# Altium schematic sheet Power.SchDoc(Power)
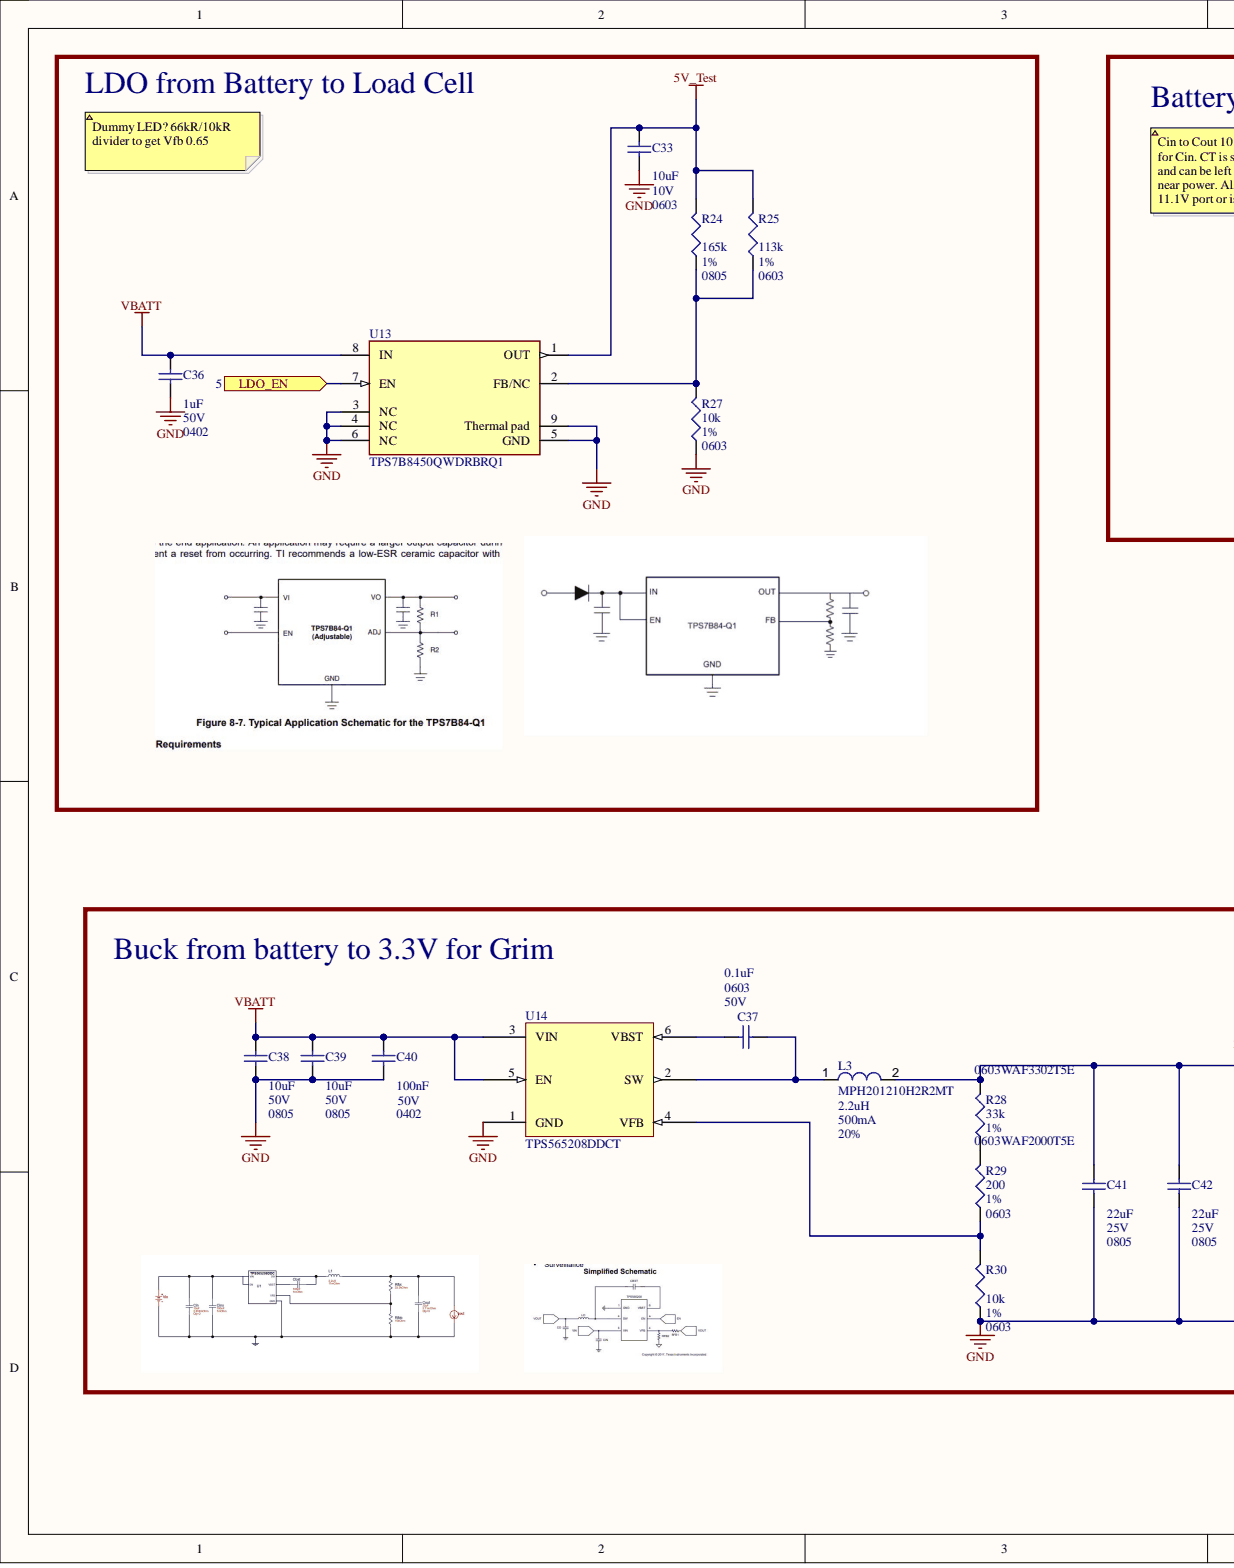
[diagram p0: Power.SchDoc, left]
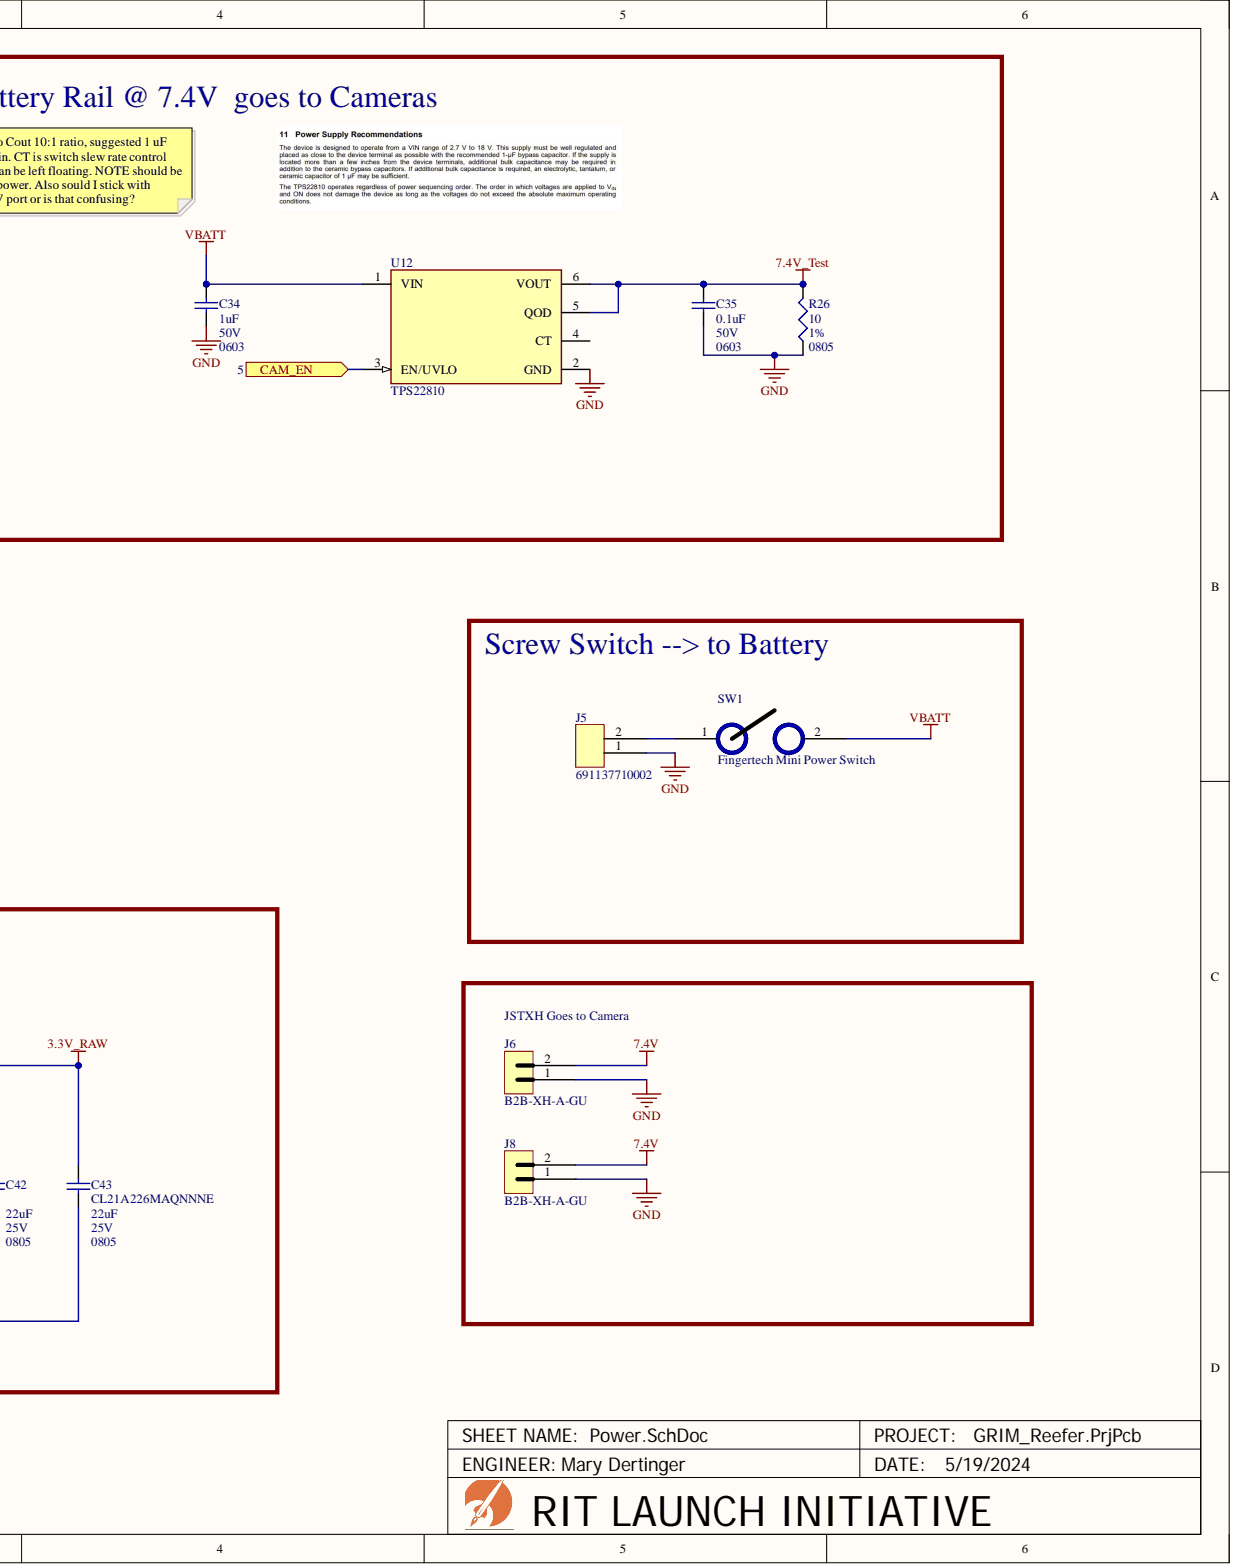
[diagram p1: Power.SchDoc, right]

## Parts
C33  Capacitance: 10uF  Voltage: 10V  (hidden comment: CL10A106KP8NNNC)
C34  Capacitance: 1uF  Case Code (Imperial): 0603  Voltage: 50V  (hidden comment: CL10A105KB8NNNC)
C35  Capacitance: 0.1uF  Case Code (Imperial): 0603  Voltage: 50V  (hidden comment: CC0603KRX7R9BB104)
C36  Capacitance: 1uF  Case/Package: 0402  Voltage: 50V  (hidden comment: CL05A105KA5NQNC)
C37  Case Code (Imperial): 0603  Voltage: 50V  (hidden comment: CC0603KRX7R9BB104)
C38  Capacitance: 10uF  Case/Package: 0805  Voltage: 50V  (hidden comment: GRM21BR61H106KE43L)
C39  Capacitance: 10uF  Case/Package: 0805  Voltage: 50V  (hidden comment: GRM21BR61H106KE43L)
C40  Capacitance: 100nF  Case/Package: 0402  Voltage: 50V  (hidden comment: CL05B104KB54PNC)
C41  CL21A226MAQNNNE  Capacitance: 22uF  Case/Package: 0805  Voltage: 25V
C42  CL21A226MAQNNNE  Capacitance: 22uF  Case/Package: 0805  Voltage: 25V
C43  CL21A226MAQNNNE  Capacitance: 22uF  Case/Package: 0805  Voltage: 25V
J5  691137710002
J6  B2B-XH-A-GU
J8  B2B-XH-A-GU
L3  MPH201210H2R2MT  Current Rating: 500mA  Inductance: 2.2uH
R24  Case/Package: 0805  Resistance: 165k  Tolerance: 1%  (hidden comment: RK73H2ATTD1653F)
R25  Case/Package: 0603  Resistance: 113k  Tolerance: 1%  (hidden comment: ARG03FTC1133)
R26  Case/Package: 0805  Tolerance: 1%  (hidden comment: 0805W8F100JT5E)
R27  Case/Package: 0603  Resistance: 10k  Tolerance: 1%  (hidden comment: 0603WAF1002T5E)
R28  0603WAF3302T5E  Resistance: 33k  Tolerance: 1%
R29  0603WAF2000T5E  Case/Package: 0603  Tolerance: 1%
R30  Case/Package: 0603  Resistance: 10k  Tolerance: 1%  (hidden comment: 0603WAF1002T5E)
SW1  Fingertech Mini Power Switch
U12  TPS22810
U13  TPS7B8450QWDRBRQ1
U14  TPS565208DDCT

## Ports
CAM_EN, LDO_EN

## Nets
3.3V_RAW: C41.2 C42.2 C43.2 L3.2 R28.2
5V_Test: C33.2 R24.2 R25.2 U13.1(OUT)
7.4V: J6.2 J8.2
7.4V_Test: C35.2 R26.2 U12.5(QOD) U12.6(VOUT)
GND: C33.1 C34.1 C35.1 C36.1 C38.1 C39.2 C40.1 C41.1 C42.1 C43.1 J5.1 J6.1 J8.1 R26.1 R27.1 R30.1 U12.2(GND) U13.3(NC) U13.4(NC) U13.5(GND) U13.6(NC) U13.9(Thermal_pad) U14.1(GND)
VBATT: C34.2 C36.2 C38.2 C39.1 C40.2 SW1.2 U12.1(VIN) U13.8(IN) U14.3(VIN) U14.5(EN)
CAM_EN: U12.3(EN/UVLO) ; port CAM_EN
LDO_EN: U13.7(EN) ; port LDO_EN
NetC37_1: C37.1 L3.1 U14.2(SW)
NetC37_2: C37.2 U14.6(VBST)
NetJ5_2: J5.2 SW1.1
NetR24_1: R24.1 R25.1 R27.2 U13.2(FB/NC)
NetR28_1: R28.1 R29.2
NetR29_1: R29.1 R30.2 U14.4(VFB)

Not connected: U12 4(CT)

## Library data of the parts
C33 = CMP-001-0266-1: CAP CER 10UF 10V X5R 0603; Material: Ceramic; Tolerance: 10%; Case/Package: 0603; Component Kind: Standard; Construction: Flat; Contact Plating: Tin; Depth: 0.8mm; Dielectric: X5R; Height: 0.8mm; JLCPCB Part #: C19702; Lead Free: Lead Free; Length: 1.6mm; Max Operating Temperature: 85°C; Min Operating Temperature: -55°C; Mounting Technology: Surface Mount; Package Quantity: 1; Packaging: Tape and Reel; Radiation Hardening: No; RoHS Compliant: Yes; Series: CL; Thickness: 0.035inch; Width: 0.031inch; Library Name: RIT Launch Initiative
C34 = CMP-001-0307-1: CAP CER 1UF 50V X5R 0603; Tolerance: 10%; Case Code (Metric): 1608; Case/Package: 0603; Component Kind: Standard; Construction: Flat; Contact Plating: Tin; Depth: 0.8mm; Dielectric: X5R; JLCPCB Part #: C15849; Length: 1.6mm; Max Operating Temperature: 85°C; Min Operating Temperature: -55°C; Mounting Technology: Surface Mount; Package Quantity: 1; Packaging: Tape and Reel; Radiation Hardening: No; RoHS Compliant: Yes; Series: CL; Termination: Wraparound; Thickness: 0.035inch; Width: 0.031inch; Library Name: RIT Launch Initiative
C35 C37 = CMP-001-0242-3: CAP CER 0.1UF 50V X7R 0603; Dielectric Material: Ceramic; Tolerance: 10%; Case Code (Metric): 1608; Case/Package: 0603; Component Kind: Standard; Depth: 0.8mm; Dielectric: X7R; Halogen Free: Halogen Free; Height: 0.8mm; JLCPCB Part #: C14663; Lead Free: Lead Free; Length: 0.063inch; Max Operating Temperature: 125°C; Min Operating Temperature: -55°C; Mount: Surface Mount; Number of Pins: 2; Package Quantity: 1; Packaging: Tape and Reel; Radiation Hardening: No; REACH SVHC: No SVHC; RoHS Compliant: Yes; Series: CC; Termination: SMD/SMT; Thickness: 0.035inch; Voltage Rating: 50V; Width: 0.031inch; Library Name: RIT Launch Initiative
  C37: Capacitance: 0.1uF
C36 = CMP-001-0296-1: CAP CER 1uF 50V X5R 0402; Material: Ceramic; Tolerance: 10%; Component Kind: Standard; Dielectric: X5R; JLCPCB Part #: C52923; Max Operating Temperature: 85°C; Min Operating Temperature: -55°C; Mounting Technology: Surface Mount; Series: CL; Library Name: RIT Launch Initiative
C38 C39 = CMP-001-0345-1: CAP CER 10UF 50V X5R 0805; Tolerance: 10%; Component Kind: Standard; Dielectric: X5R; JLCPCB Part #: C440198; Length: 2mm; Max Operating Temperature: 85°C; Min Operating Temperature: -55°C; Mounting Technology: Surface Mount; Series: GRM; Thickness: 1.25mm; Width: 1.25mm; Library Name: RIT Launch Initiative
C40 = CMP-001-0348-1: CAP CER 0.1UF 50V X7R 0402; Component Kind: Standard; JLCPCB Part #: C307331; RoHS Compliant: Yes; Library Name: RIT Launch Initiative
C41-C43 = CMP-001-0346-1: CAP CER 22UF 25V X5R 0805; Component Kind: Standard; JLCPCB Part #: C45783; Library Name: RIT Launch Initiative
J5 = CMP-001-0301-1: TERM BLK 2POS SIDE ENTRY 5MM PCB; Current: 16A; Number of Levels: 1; Number of Positions Per Level: 2; Plating: Copper Alloy; Voltage: 300V; Wire Gauge (Max): 30AWG; Wire Gauge (Min): 12AWG; Color: Green; Component Kind: Standard; Housing Material: PC; Max Operating Temperature: 120°C; Min Operating Temperature: -30°C; Mounting Technology: Through Hole; Packaging: Bulk; RoHS Compliant: Yes; Termination: Screw; Library Name: RIT Launch Initiative
J6 J8 = CMP-001-0220-2: CONN HEADER VERT 2POS 2.5MM; Mated Stacking Heights: 9.8mm; Number of Positions: 2; Voltage Rating: 250V; Color: Natural; Component Kind: Standard; Connector Type: Header; Contact Finish - Mating: GOLD; Contact Material: Brass; Contact Plating: Gold; Contact Shape: Square; Contact Type: Male Pin; Fastening Type: Detent; Flammability Rating: UL94 V-0; Insulation Color: Natural; Insulation Material: Nylon; Lead Free: Lead Free; Max Operating Temperature: 85°C; Min Operating Temperature: -25°C; Mount: Through Hole; Number of Rows: 1; Packaging: Bulk; Pitch: 2.5mm; Series: XH; Termination: Press-Fit; Library Name: RIT Launch Initiative
L3 = CMP-001-0386-1: 功率电感 SMD; Tolerance: 20%; Component Kind: Standard; JLCPCB Part #: C279882; Library Name: RIT Launch Initiative
R24 = CMP-009-00196-1: RES SMD 165K OHM 1% 250mW 0805; Voltage: 150V; Component Kind: Standard; JLCPCB Part #: C346113; Library Name: RIT Launch Initiative
R25 = CMP-001-0393-2: RES SMD 113K OHM 1% 100mW 0603; Component Kind: Standard; JLCPCB Part #: C217697; Library Name: RIT Launch Initiative
R26 = CMP-009-00181-3: 10Ohm - 0805 - 125mW Thick Film Resistors 150V ±1% ±200ppm/℃ -55℃~+155℃; Resistance: 10; Voltage: 150; Component Kind: Standard; JLCPCB Part #: C17415; Library Name: RIT Launch Initiative
R27 R30 = CMP-001-0268-1: RES 10K OHM 1% 1/10W 0603; Component Kind: Standard; JLCPCB Part #: C25804; RoHS Compliant: Yes; Library Name: RIT Launch Initiative
R28 = CMP-001-0347-1: RES 33K OHM 1% 1/10W 0603; Component Kind: Standard; JLCPCB Part #: C4216; Library Name: RIT Launch Initiative
R29 = CMP-001-0385-1: RES SMD 200 OHM 1% 1/10W 0603; Manufacturer Series: 0603WA; Power: 100mW; Rated Temperature: 70°C; Resistance: 200; Temperature Coefficient: 100ppm/°C; Value: 200R; Working Voltage: 50V; Component Kind: Standard; JESD-609 Code: e3; JLCPCB Part #: C8218; Max Operating Temperature: 155°C; Min Operating Temperature: -55°C; Mounting Technology: Surface Mount; Package Shape: Rectangular; Packaging: Tape and Reel; Pins: 2; Resistor Type: FIXED RESISTOR; RoHS Compliant: Yes; Technology: METAL GLAZE/THICK FILM; Terminal Finish: Tin; Termination: Wraparound; Library Name: RIT Launch Initiative
SW1 = CMP-001-0399-2: Component Kind: Standard; Description: Fingertech Mini Power Switch; Library Name: RIT Launch Initiative
U12 = CMP-001-0387-2: Buffer/Inverter Based Peripheral Driver, 1 Driver, PDSO6; Built-in Protections: Under Voltage,TRANSIENT,THERMAL; Channels: 1; Interface IC Type: BUFFER OR INVERTER BASED PERIPHERAL DRIVER; Max Supply Voltage: 18V; Min Supply Voltage: 2.7V; Nominal Supply Voltage: 3.3V; Output Current Flow Direction: SINK; Package Body Material: Plastic; Case/Package: LSSOP; Component Kind: Standard; Height - Seated (Max): 1.45mm; JESD-30 Code: R-PDSO-G6; JESD-609 Code: e4; JLCPCB Part #: C527679; Length: 2.9mm; Max Operating Temperature: 105°C; Min Operating Temperature: -40°C; Moisture Sensitivity Level: 2; Package Shape: Rectangular; Package Style: SMALL OUTLINE, LOW PROFILE, SHRINK PITCHMeter; Pins: 6; Reach SVHC Compliant: Yes; RoHS Compliant: Yes; Surface Mount: Yes; Temperature Grade: INDUSTRIAL; Terminal Finish: Nickel; Terminal Form: Gull Wing; Terminal Pitch: 0.95mm; Terminal Position: DUAL; Width: 1.65mm; Library Name: RIT Launch Initiative
U13 = CMP-001-0390-1: Fixed Positive LDO Regulator, 5V, 0.255V Dropout, PDSO8; Component Kind: Standard; Library Name: RIT Launch Initiative
U14 = CMP-019-00052-5: 4.5V to 17V, 5A Synchronous Step-Down Voltage Regulator in SOT23 6-SOT-23-THIN -40 to 125; Component Kind: Standard; JLCPCB Part #: C2650336; Library Name: RIT Launch Initiative
